# Altium schematic sheet PE.SchDoc
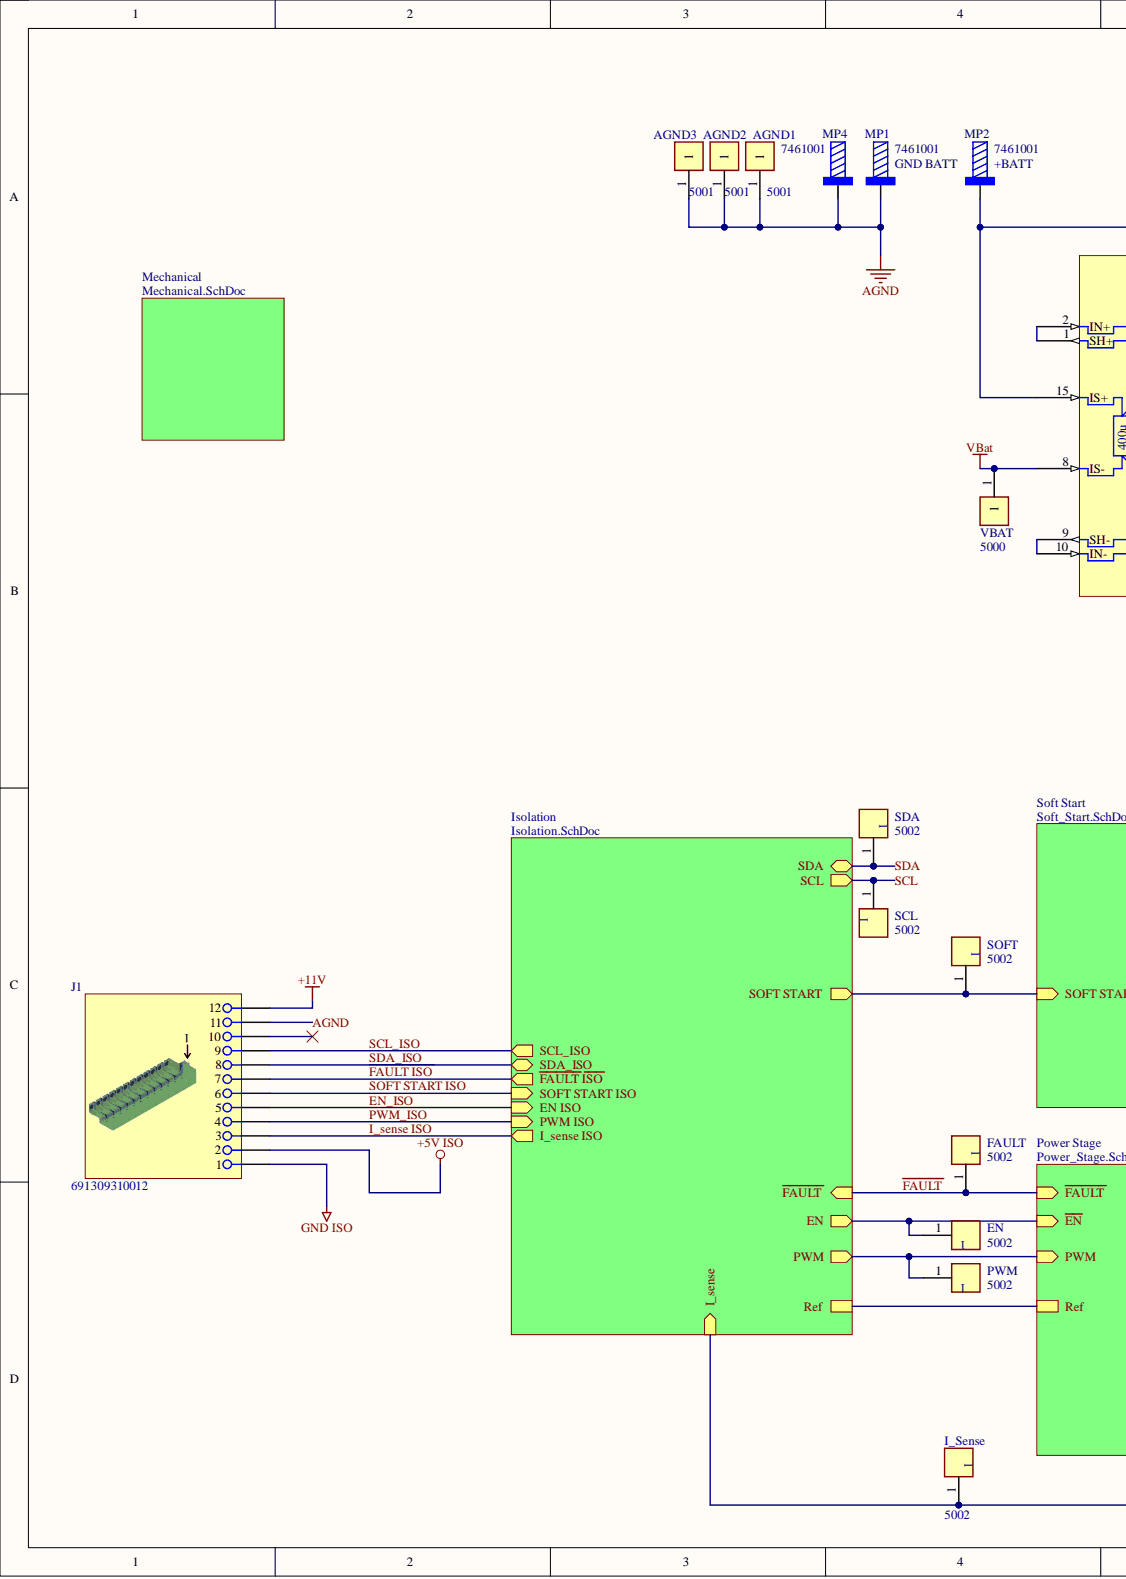
[diagram p0: PE.SchDoc, left]
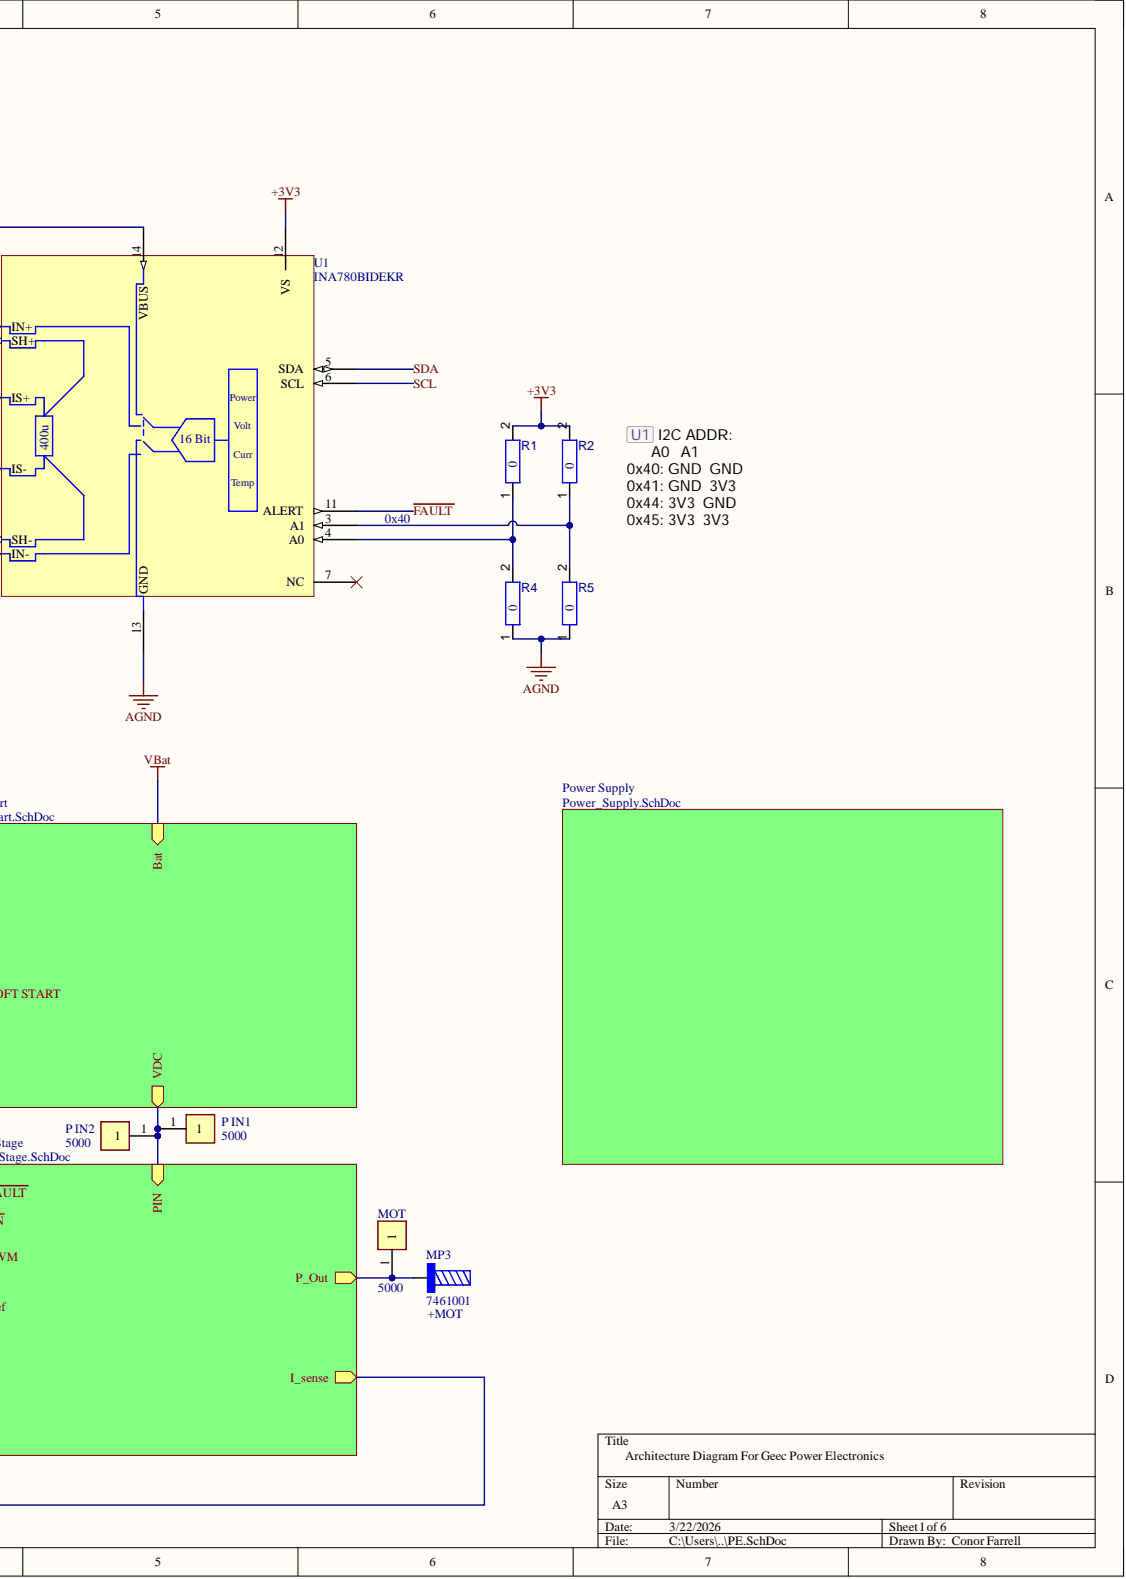
[diagram p1: PE.SchDoc, right]

## Parts
AGND1  5001  [FP-5001-MFG]
AGND2  5001  [FP-5001-MFG]
AGND3  5001  [FP-5001-MFG]
EN  5002  [FP-5002-MFG]
FAULT  5002  [FP-5002-MFG]
I_Sense  5002  [FP-5002-MFG]
J1  691309310012  [691309310012]
MOT  5000  [FP-5000-MFG]
MP1  7461001  [7461001_chemical surface]
MP2  7461001  [7461001_chemical surface]
MP3  7461001  [7461001_chemical surface]
MP4  7461001  [7461001_chemical surface]
P_IN1  5000  [FP-5000-MFG]
P_IN2  5000  [FP-5000-MFG]
PWM  5002  [FP-5002-MFG]
R1  value 0  [RESC3216X60X45NL15T20]  (hidden comment: 1206W4F0000T5E)
R2  value 0  [RESC3216X60X45NL15T20]  (hidden comment: 1206W4F0000T5E)
R4  value 0  [RESC3216X60X45NL15T20]  (hidden comment: 1206W4F0000T5E)
R5  value 0  [RESC3216X60X45NL15T20]  (hidden comment: 1206W4F0000T5E)
SCL  5002  [FP-5002-MFG]
SDA  5002  [FP-5002-MFG]
SOFT  5002  [FP-5002-MFG]
U1  INA780BIDEKR  [VQFN15_DEK_TEX]
VBAT  5000  [FP-5000-MFG]

## Nets
+3V3: R1.2 R2.2 U1.12(VS)
+5V ISO: J1.2
+11V: J1.12
GND ISO: J1.1
VBat: U1.8(IS-) VBAT.1
~{FAULT}: FAULT.1 U1.11(ALERT)
~{FAULT ISO}: J1.7
AGND: AGND1.1 AGND2.1 AGND3.1 J1.11 MP1.1 MP4.1 R4.1 R5.1 U1.13(GND)
EN_ISO: J1.5
I_sense ISO: J1.3
PWM_ISO: J1.4
SCL: SCL.1 U1.6(SCL)
SCL_ISO: J1.9
SDA: SDA.1 U1.5(SDA)
SDA_ISO: J1.8
SOFT START ISO: J1.6
NetEN_1: EN.1
NetI_Sense_1: I_Sense.1
NetJ1_10: J1.10
NetMOT_1: MOT.1 MP3.1
NetMP2_1: MP2.1 U1.14(VBUS) U1.15(IS+)
NetP IN1_1: P_IN1.1 P_IN2.1
NetPWM_1: PWM.1
NetR1_1: R1.1 R4.2 U1.4(A0)
NetR2_1: R2.1 R5.2 U1.3(A1)
NetSOFT_1: SOFT.1
NetU1_1: U1.1(SH+) U1.2(IN+)
NetU1_7: U1.7(NC)
NetU1_9: U1.9(SH-) U1.10(IN-)
Ref:

## Library data of the parts
AGND1-AGND3 = CMP-00030-00001-2: Test Point, Mini, Thm, Pc, .300 L, .100 Od, Heat Resistant Nylon 46, Black; Hole Diameter: 1.6mm; Color: Black; Component Kind: Standard; Mounting Technology: PCB, Through Hole; Pins: 1; Library Name: University of Galway
EN FAULT I_Sense PWM SCL SDA SOFT = CMP-00030-00002-2: Test Point, Mini, Thm, Pc, .300 L, .100 Od, Heat Resistant Nylon 46, White; Hole Diameter: 1.6mm; Color: White; Component Kind: Standard; Mounting Technology: PCB, Through Hole; Library Name: University of Galway
J1 = CMP-002-00074-3: Terminal Block, 3.81MM Pitch, Horizontal With Coding Finger, Tht, 12 Pins; Gender: Female; System: Connector; Component Kind: Standard; MPN: 691309310012; Library Name: University of Galway
MOT P_IN1 P_IN2 VBAT = CMP-00030-00004-2: Cable Wrap, 1/2 Inch, Polyethylene, Natural, 50 Ft, Spirap Series; Hole Diameter: 1.6mm; Color: Red; Component Kind: Standard; Mounting Technology: PCB, Through Hole; Library Name: University of Galway
MP1-MP4 = CMP-002-00075-3: Pcb Terminal, Brass, Press-Fit Rohs Compliant: Yes; Current Rating: 130A; Component Kind: Standard; Height: 12mm; Material: Brass; Max Operating Temperature: 150°C; Min Operating Temperature: -55°C; Pins: 9; Library Name: University of Galway
R1 R2 R4 R5 = CMP-009-00183-1: Thick Film Resistors - SMD RMC 1206 1/4W 1% T/R-5000; Case/Package: 1206; Component Kind: Standard; JLCPN: C17888; Library Name: University of Galway
U1 = CMP-004-000000-8: 85-V 16-bit I²C-output digital power monitor with 400-ÂµOhm EZShunt Technology 15-VQFN -40 to 125; Manufacturer_Name: Texas Instruments; Manufacturer_Part_Number: PINA780BIDEKR; Case/Package: VQFN; Component Kind: Standard; Datasheet: https://www.ti.com/lit/gpn/ina780b; Design Item ID: PINA780BIDEKR; JLCPN: C34740774; MPN: INA780BIDEKR; Pins: 15; RefDes: RefDes; Source: PINA780BIDEKR.SchLib; Type: Linear; Copyright: Copyright (C) 2025 Ultra Librarian. All rights reserved.; Library Name: University of Galway
